# Altium schematic sheet Main.SchDoc(Main)
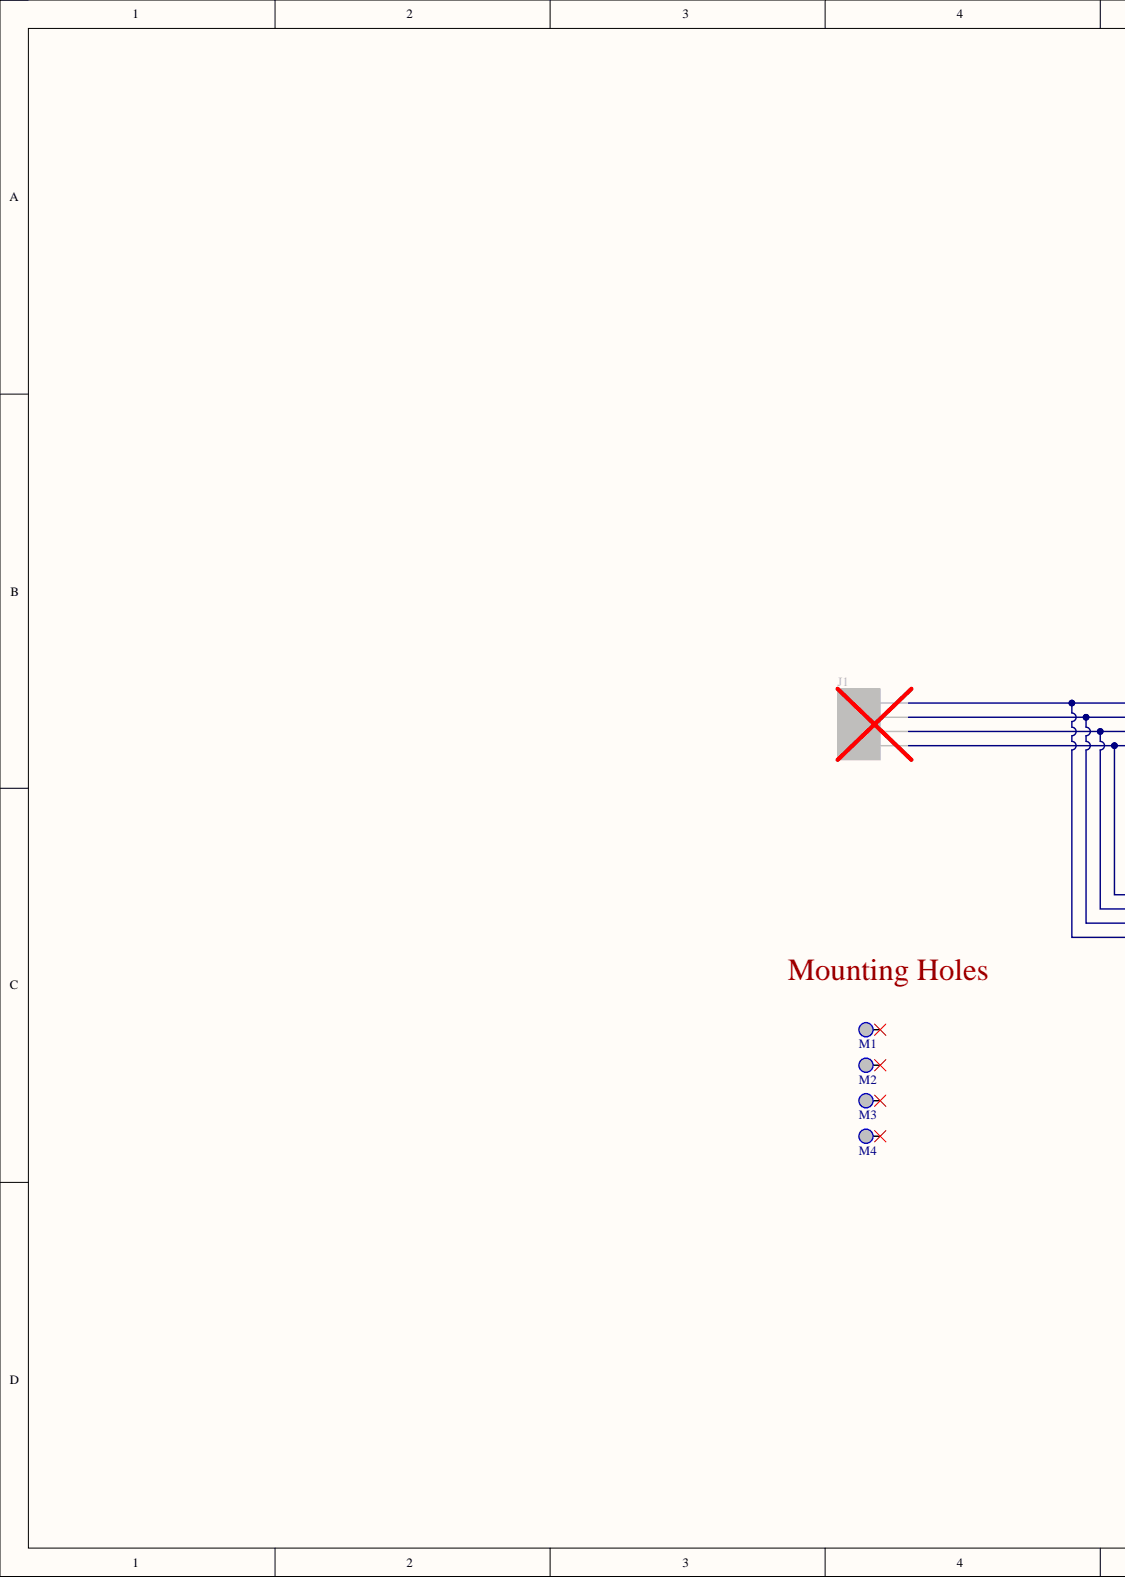
[diagram p0: Main.SchDoc, left]
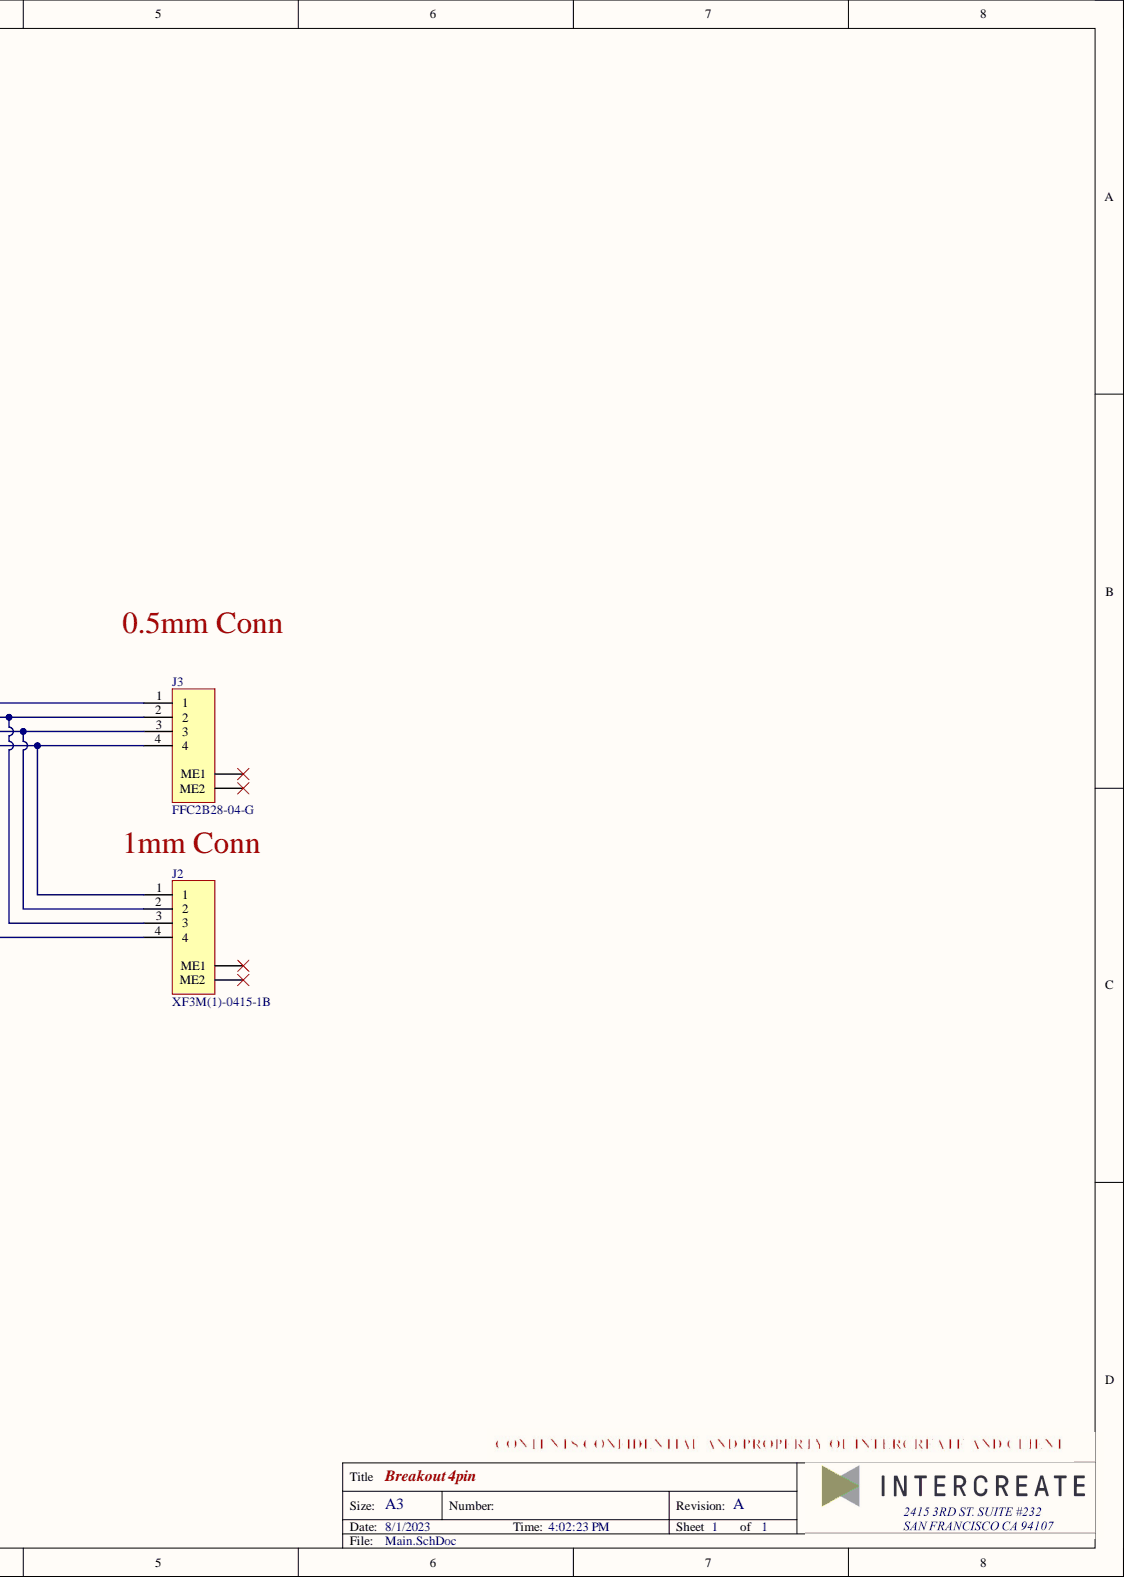
[diagram p1: Main.SchDoc, right]

## Parts
J1  61300411121  [HDR-V-1X4]  (value not drawn)
J2  XF3M(1)-0415-1B  Pitch: 1mm  [CONN-FFC-H-4P-1MM]
J3  FFC2B28-04-G  [CONN-FFC-H-4P-0.5MM]
M1  MTG_HOLE  [MH_P_2.5MM]  (value not drawn)  (no BOM)
M2  MTG_HOLE  [MH_P_2.5MM]  (value not drawn)  (no BOM)
M3  MTG_HOLE  [MH_P_2.5MM]  (value not drawn)  (no BOM)
M4  MTG_HOLE  [MH_P_2.5MM]  (value not drawn)  (no BOM)

## Nets
NetJ1_1: J1.1 J2.4 J3.1
NetJ1_2: J1.2 J2.3 J3.2
NetJ1_3: J1.3 J2.2 J3.3
NetJ1_4: J1.4 J2.1 J3.4

Not connected: J2 ME1 ME2; J3 ME1 ME2; M1 1; M2 1; M3 1; M4 1

## Library data of the parts
J1 = HDR-V-1X4-M: Header, 4-Pin, 0.1"; Manufacturer 1: Wurth Electronics; Connector Type: Male Pin; Gender: Male; Number of Contacts: 4; Pitch: 0.1inch; Color: Black; Component Kind: Standard; ComponentLink1Description: http://www.farnell.com/cad/1737268.pdf; ComponentLink1URL: http://www.farnell.com/cad/1737268.pdf; ComponentLink2Description: http://katalog.we-online.de/em/datasheet/6130xx11121.pdf; ComponentLink2URL: http://katalog.we-online.de/em/datasheet/6130xx11121.pdf; ComponentLink3Description: http://www.farnell.com/cad/1737268.pdf?src-supplier=Element14; ComponentLink3URL: http://www.farnell.com/cad/1737268.pdf?src-supplier=Element14; ComponentLink4Description: https://katalog.we-online.de/em/datasheet/6130xx11121.pdf?src-supplier=Digi-Key; ComponentLink4URL: https://katalog.we-online.de/em/datasheet/6130xx11121.pdf?src-supplier=Digi-Key; Contact Material: Copper; Contact Mating Length: 0.236inch; Contact Plating: Gold; Lead Free: Lead Free; Manufacturer Part Number 1: 61300411121; Mount: Through Hole; Number of Positions: 4; Number of Rows: 1; Packaging: Bulk; Pricing 1: 1=0.18000, 10=0.15300, 25=0.12720, 50=0.10600, 100=0.09600, 1000=0.08800 (USD); REACH SVHC: Unknown; RoHS: Yes; Signal Integrity: Connector; Stock 1: 25747; Supplier 1: Digi-Key; Supplier Part Number 1: 732-5317-ND; Termination: Solder; Library Name: intercreate.IntLib
J2 = CONN-FFC-H-4P-1MM: FFC & FPC Connectors RotaryBackLock 1.0mm Dual Contact 4pin; Manufacturer 1: Omron; Actuator Color: Black; Actuator Material: Polymer,Liquid Crystal Polymer; Current Rating: 500mA; Gender: Female; Insulation Resistance: 100MR; Mating Cycles: 20; Number of Contacts: 4; Voltage Rating: 50V; Category: FFC / FPC; Component Kind: Standard; ComponentLink1Description: https://datasheet.ciiva.com/29758/xf3m-0813-29758592.pdf?src-supplier=Digi-Key; ComponentLink1URL: https://datasheet.ciiva.com/29758/xf3m-0813-29758592.pdf?src-supplier=Digi-Key; ComponentLink2Description: https://datasheet.ciiva.com/29758/xf3m-0813-29758601.pdf?src-supplier=Digi-Key; ComponentLink2URL: https://datasheet.ciiva.com/29758/xf3m-0813-29758601.pdf?src-supplier=Digi-Key; ComponentLink3Description: https://datasheet.ciiva.com/43511/xf3m-0813-43511125.pdf?src-supplier=Onlinecomponents.com; ComponentLink3URL: https://datasheet.ciiva.com/43511/xf3m-0813-43511125.pdf?src-supplier=Onlinecomponents.com; Contact Location: Dual; Contact Material: Copper Alloy; Contact Plating: Gold; Flammability Rating: UL94 V-0; Housing Color: Natural; Housing Material: Polymer; Lead Free: Lead Free; Manufacturer Part Number 1: XF3M(1)-0415-1B; Max Operating Temperature: 85°C; Min Operating Temperature: -30°C; Mounting Technology: Surface Mount; Number of Positions: 4; Number of Rows: 1; Orientation: Right Angle; Package Quantity: 1; Packaging: Tape and Reel; Pricing 1: 1500=0.6934, 3000=0.542, 6000=0.5365, 12000=0.5311, 15000=0.5257, 24000=0.5204, 30000=0.5152 (USD); Radiation Hardening: No; RoHS: Yes; RoHS Compliant: Yes; Series: XF3M; Stock 1: 10500; Supplier 1: Arrow Electronics; Supplier Part Number 1: XF3M(1)-0415-1B; Termination: Solder; Library Name: intercreate.IntLib
J3 = CONN-FFC-H-4P-0.5MM: 4W, 0.5MM Ffc Con, R/a, Dual Cont; Manufacturer 1: Global Connector Technology; Category: Connectors; Component Kind: Standard; Manufacturer Part Number 1: FFC2B28-04-G; Pricing 1: 4000=0.276, 5000=0.253 (USD); RoHS: No; Stock 1: 4000; Supplier 1: Newark; Supplier Part Number 1: 90AJ6003; Library Name: intercreate.IntLib
M1-M4 = MTG_HOLE: MTG HOLE PLATED VARIOUS SIZES; Library Name: intercreate.IntLib
